# Altium schematic sheet Top.SchDoc(Top)
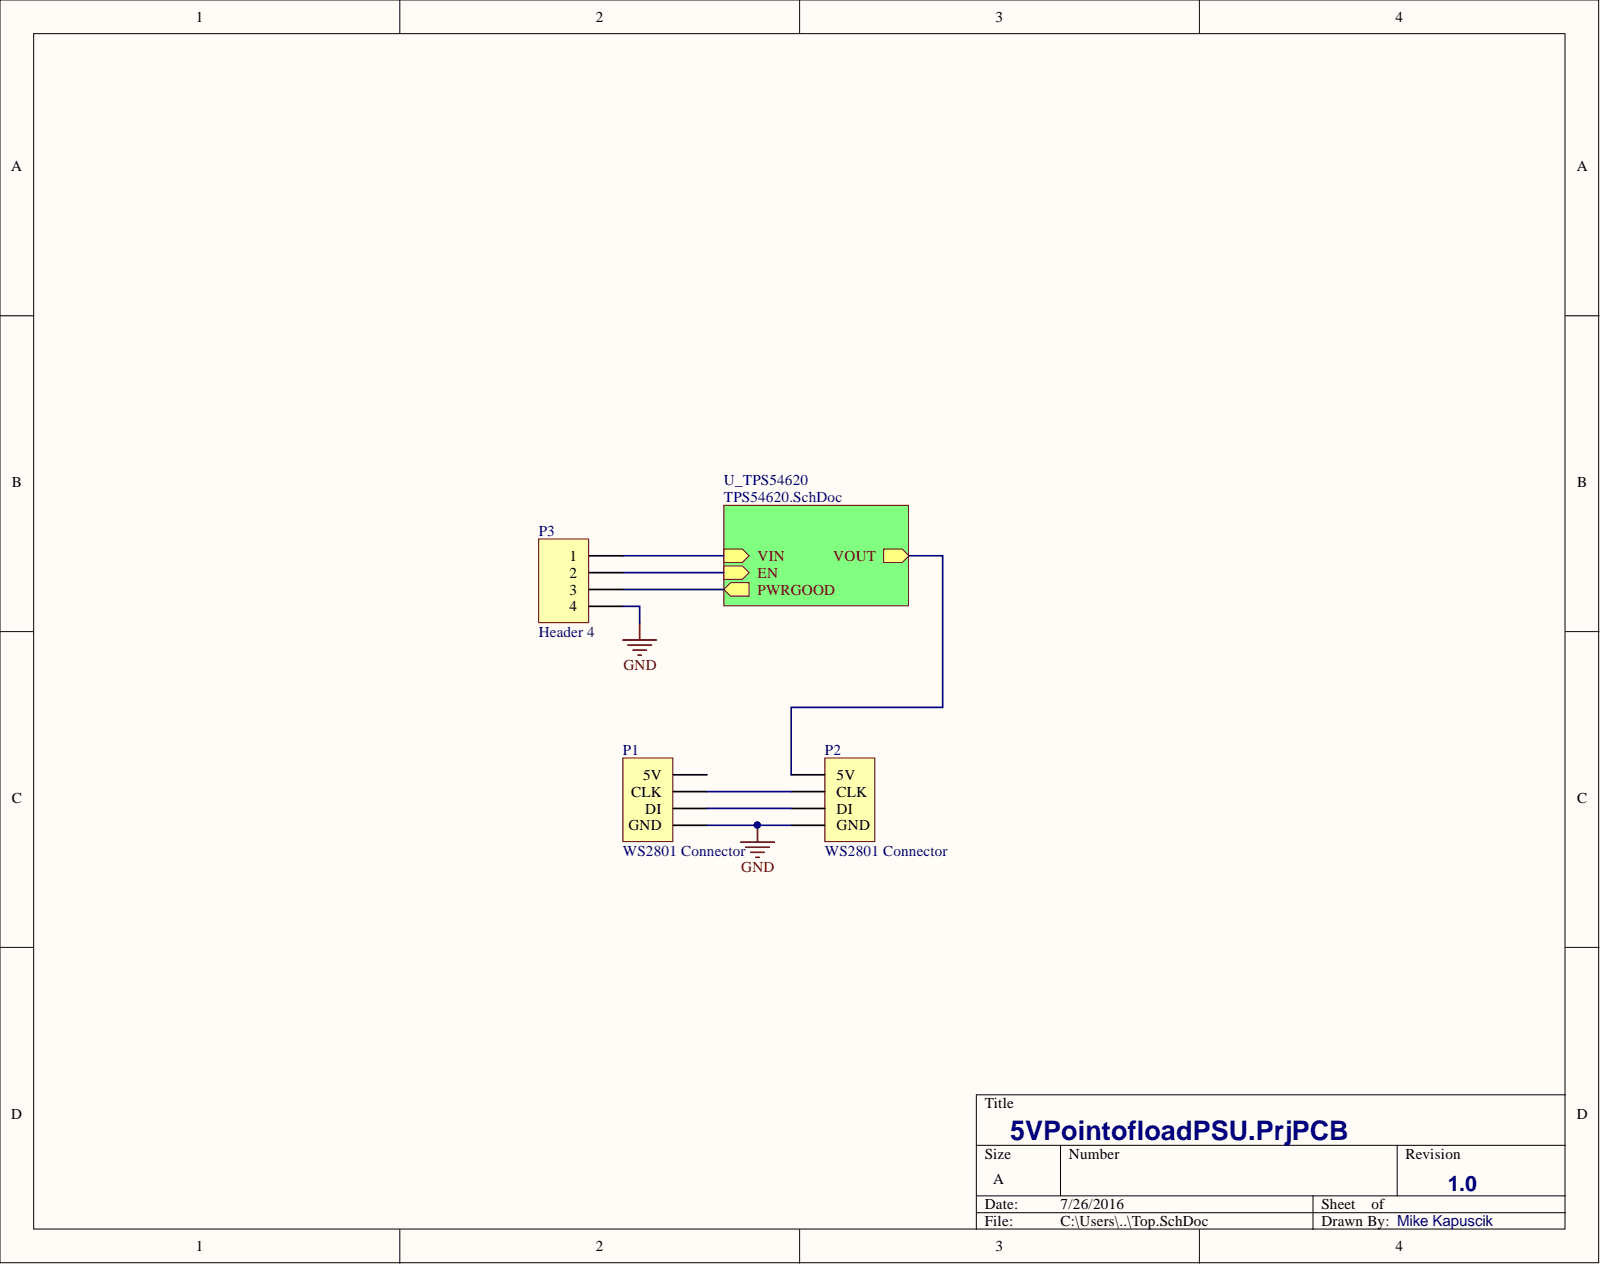
[diagram p0: Top.SchDoc]

## Parts
P1  WS2801 Connector  [HDR1X4]
P2  WS2801 Connector  [HDR1X4]
P3  Header 4  [HDR1X4]

## Nets
GND: P1.4(GND) P2.4(GND) P3.4
NetP1_1: P1.1(5V)
NetP1_2: P1.2(CLK) P2.2(CLK)
NetP1_3: P1.3(DI) P2.3(DI)
NetP2_1: P2.1(5V)
NetP3_1: P3.1
NetP3_2: P3.2
NetP3_3: P3.3

## Library data of the parts
P1 P2 = WS2801 Connector: Header, 4-Pin; Component Kind: Standard; LatestRevisionDate: 17-Jul-2002; LatestRevisionNote: Re-released for DXP Platform.; Library Name: Miscellaneous Connectors.SchLib; Signal Integrity: Connector; Publisher: Altium Limited
P3 = Header 4: Header, 4-Pin; Component Kind: Standard; LatestRevisionDate: 17-Jul-2002; LatestRevisionNote: Re-released for DXP Platform.; Library Name: Miscellaneous Connectors.SchLib; Signal Integrity: Connector; Publisher: Altium Limited
